# Altium schematic sheet Voltage_Sense.SchDoc
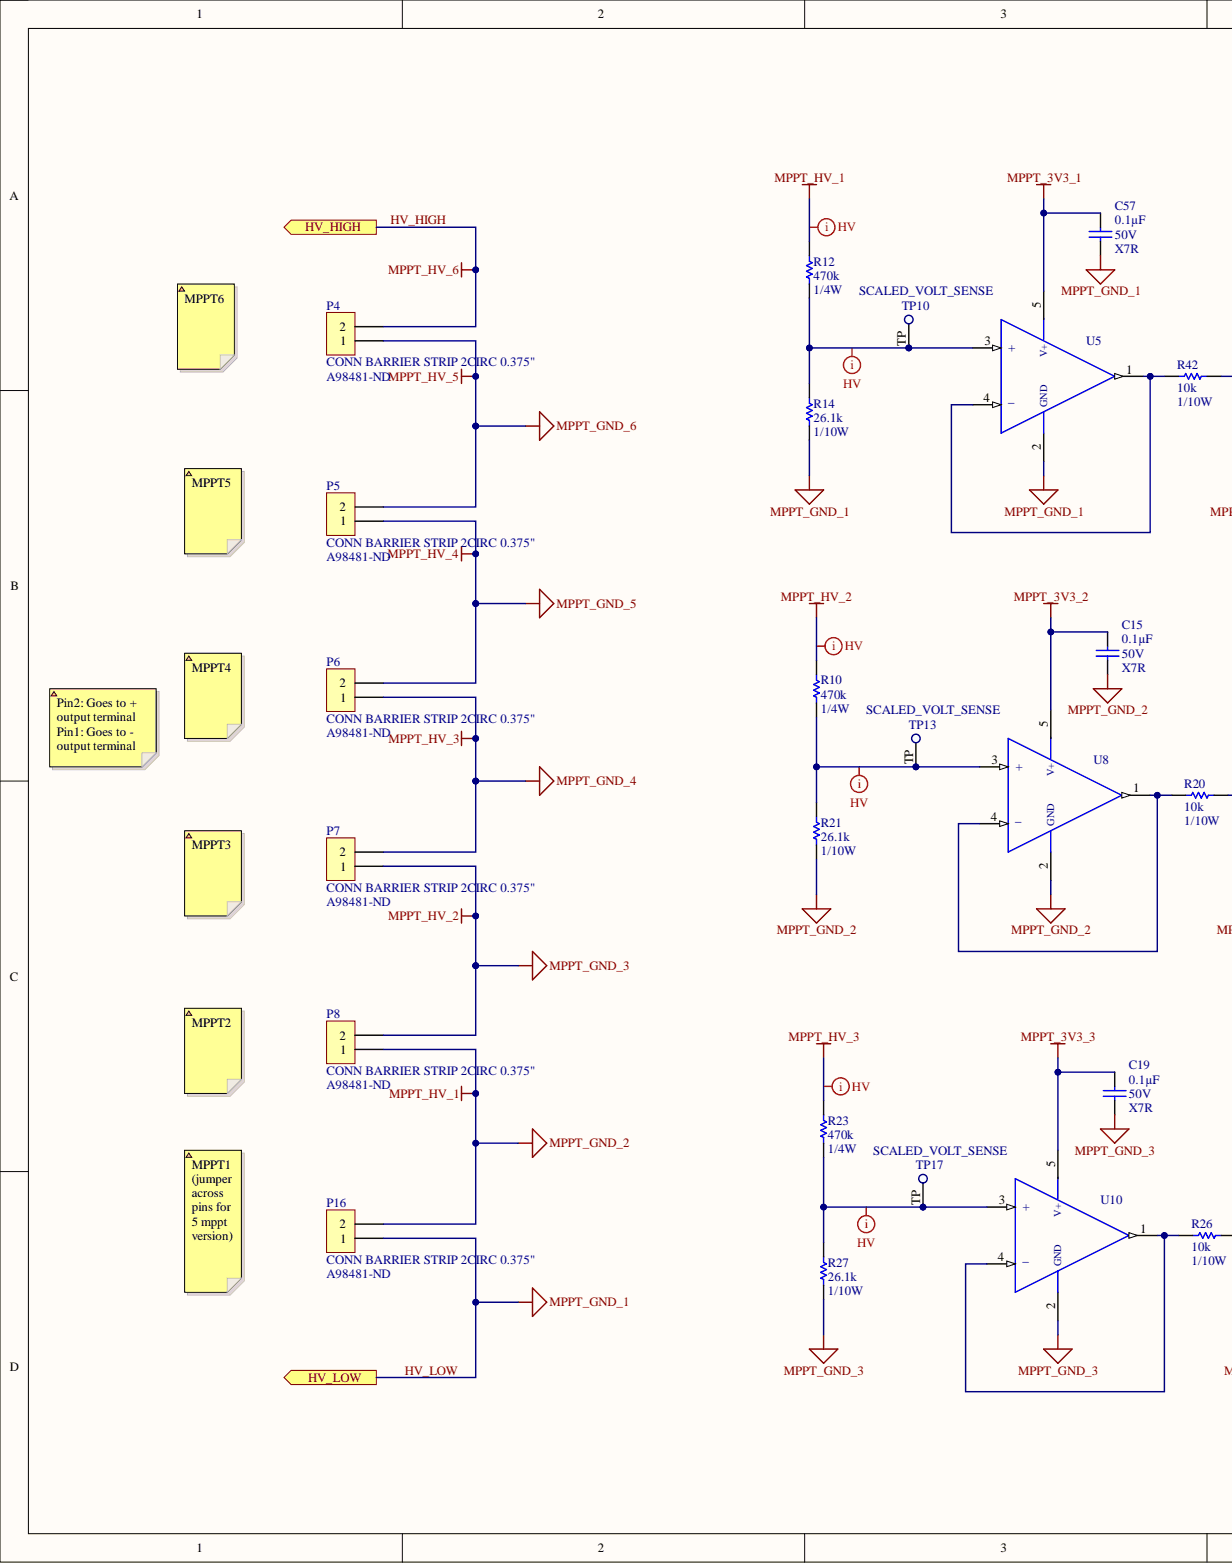
[diagram p0: Voltage_Sense.SchDoc, left]
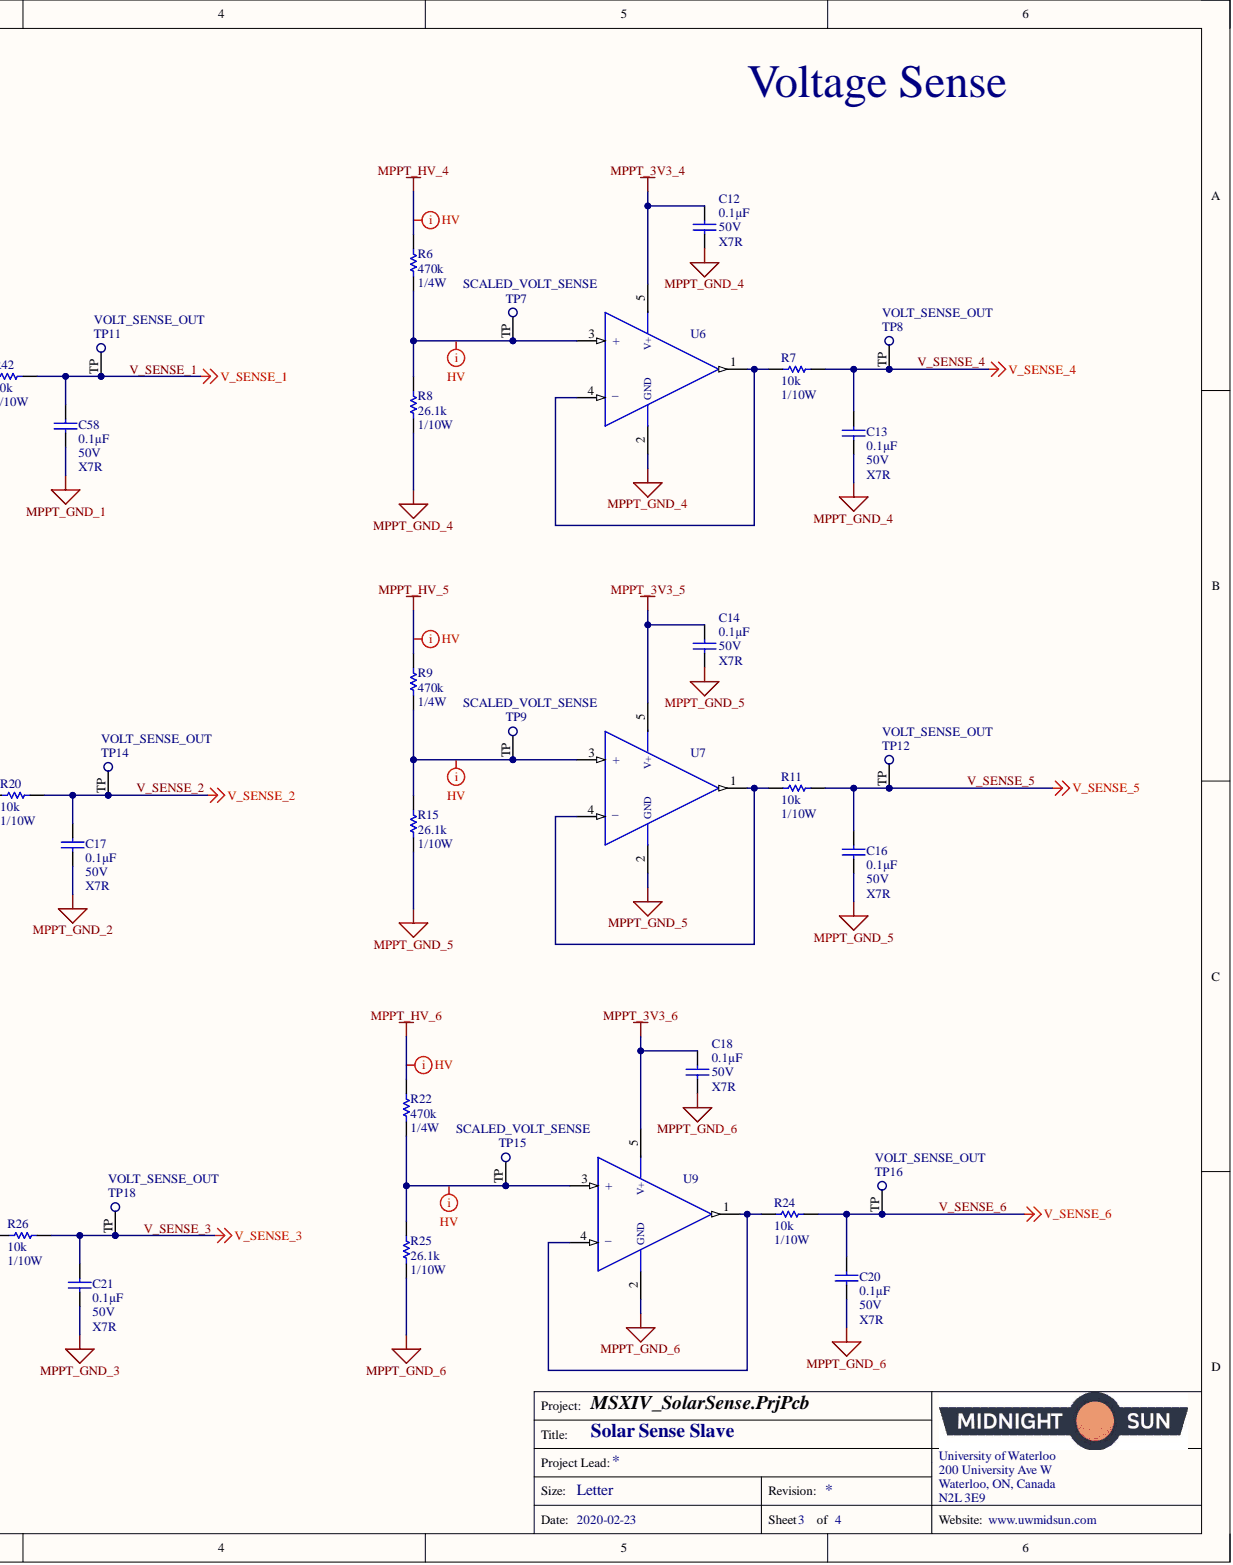
[diagram p1: Voltage_Sense.SchDoc, right]

## Parts
C12  Capacitance: 0.1µF  Temperature Coefficient: X7R  Voltage: 50V  [CAP, 0603]  (hidden comment: CAP CER 0.1UF 50V 10% X7R 0603)
C13  Capacitance: 0.1µF  Temperature Coefficient: X7R  Voltage: 50V  [CAP, 0603]  (hidden comment: CAP CER 0.1UF 50V 10% X7R 0603)
C14  Capacitance: 0.1µF  Temperature Coefficient: X7R  Voltage: 50V  [CAP, 0603]  (hidden comment: CAP CER 0.1UF 50V 10% X7R 0603)
C15  Capacitance: 0.1µF  Temperature Coefficient: X7R  Voltage: 50V  [CAP, 0603]  (hidden comment: CAP CER 0.1UF 50V 10% X7R 0603)
C16  Capacitance: 0.1µF  Temperature Coefficient: X7R  Voltage: 50V  [CAP, 0603]  (hidden comment: CAP CER 0.1UF 50V 10% X7R 0603)
C17  Capacitance: 0.1µF  Temperature Coefficient: X7R  Voltage: 50V  [CAP, 0603]  (hidden comment: CAP CER 0.1UF 50V 10% X7R 0603)
C18  Capacitance: 0.1µF  Temperature Coefficient: X7R  Voltage: 50V  [CAP, 0603]  (hidden comment: CAP CER 0.1UF 50V 10% X7R 0603)
C19  Capacitance: 0.1µF  Temperature Coefficient: X7R  Voltage: 50V  [CAP, 0603]  (hidden comment: CAP CER 0.1UF 50V 10% X7R 0603)
C20  Capacitance: 0.1µF  Temperature Coefficient: X7R  Voltage: 50V  [CAP, 0603]  (hidden comment: CAP CER 0.1UF 50V 10% X7R 0603)
C21  Capacitance: 0.1µF  Temperature Coefficient: X7R  Voltage: 50V  [CAP, 0603]  (hidden comment: CAP CER 0.1UF 50V 10% X7R 0603)
C57  Capacitance: 0.1µF  Temperature Coefficient: X7R  Voltage: 50V  [CAP, 0603]  (hidden comment: CAP CER 0.1UF 50V 10% X7R 0603)
C58  Capacitance: 0.1µF  Temperature Coefficient: X7R  Voltage: 50V  [CAP, 0603]  (hidden comment: CAP CER 0.1UF 50V 10% X7R 0603)
P4  CONN BARRIER STRIP 2CIRC 0.375"  Supplier Part Number 1: A98481-ND  [TE_3-1437652-9]
P5  CONN BARRIER STRIP 2CIRC 0.375"  Supplier Part Number 1: A98481-ND  [TE_3-1437652-9]
P6  CONN BARRIER STRIP 2CIRC 0.375"  Supplier Part Number 1: A98481-ND  [TE_3-1437652-9]
P7  CONN BARRIER STRIP 2CIRC 0.375"  Supplier Part Number 1: A98481-ND  [TE_3-1437652-9]
P8  CONN BARRIER STRIP 2CIRC 0.375"  Supplier Part Number 1: A98481-ND  [TE_3-1437652-9]
P16  CONN BARRIER STRIP 2CIRC 0.375"  Supplier Part Number 1: A98481-ND  [TE_3-1437652-9]
R6  Power: 1/4W  Resistance: 470k  [RES, 0603]  (hidden comment: RES 470K OHM 1% 1/4W 0603)
R7  Power: 1/10W  Resistance: 10k  [RES, 0603]  (hidden comment: RES 10K OHM 1% 1/10W 0603)
R8  Power: 1/10W  Resistance: 26.1k  [RES, 0603]  (hidden comment: RES 26.1K OHM 1% 1/10W 0603)
R9  Power: 1/4W  Resistance: 470k  [RES, 0603]  (hidden comment: RES 470K OHM 1% 1/4W 0603)
R10  Power: 1/4W  Resistance: 470k  [RES, 0603]  (hidden comment: RES 470K OHM 1% 1/4W 0603)
R11  Power: 1/10W  Resistance: 10k  [RES, 0603]  (hidden comment: RES 10K OHM 1% 1/10W 0603)
R12  Power: 1/4W  Resistance: 470k  [RES, 0603]  (hidden comment: RES 470K OHM 1% 1/4W 0603)
R14  Power: 1/10W  Resistance: 26.1k  [RES, 0603]  (hidden comment: RES 26.1K OHM 1% 1/10W 0603)
R15  Power: 1/10W  Resistance: 26.1k  [RES, 0603]  (hidden comment: RES 26.1K OHM 1% 1/10W 0603)
R20  Power: 1/10W  Resistance: 10k  [RES, 0603]  (hidden comment: RES 10K OHM 1% 1/10W 0603)
R21  Power: 1/10W  Resistance: 26.1k  [RES, 0603]  (hidden comment: RES 26.1K OHM 1% 1/10W 0603)
R22  Power: 1/4W  Resistance: 470k  [RES, 0603]  (hidden comment: RES 470K OHM 1% 1/4W 0603)
R23  Power: 1/4W  Resistance: 470k  [RES, 0603]  (hidden comment: RES 470K OHM 1% 1/4W 0603)
R24  Power: 1/10W  Resistance: 10k  [RES, 0603]  (hidden comment: RES 10K OHM 1% 1/10W 0603)
R25  Power: 1/10W  Resistance: 26.1k  [RES, 0603]  (hidden comment: RES 26.1K OHM 1% 1/10W 0603)
R26  Power: 1/10W  Resistance: 10k  [RES, 0603]  (hidden comment: RES 10K OHM 1% 1/10W 0603)
R27  Power: 1/10W  Resistance: 26.1k  [RES, 0603]  (hidden comment: RES 26.1K OHM 1% 1/10W 0603)
R42  Power: 1/10W  Resistance: 10k  [RES, 0603]  (hidden comment: RES 10K OHM 1% 1/10W 0603)
TP7  SCALED_VOLT_SENSE  [Test Point]  (no BOM)
TP8  VOLT_SENSE_OUT  [Test Point]
TP9  SCALED_VOLT_SENSE  [Test Point]  (no BOM)
TP10  SCALED_VOLT_SENSE  [Test Point]  (no BOM)
TP11  VOLT_SENSE_OUT  [Test Point]
TP12  VOLT_SENSE_OUT  [Test Point]
TP13  SCALED_VOLT_SENSE  [Test Point]  (no BOM)
TP14  VOLT_SENSE_OUT  [Test Point]
TP15  SCALED_VOLT_SENSE  [Test Point]  (no BOM)
TP16  VOLT_SENSE_OUT  [Test Point]
TP17  SCALED_VOLT_SENSE  [Test Point]  (no BOM)
TP18  VOLT_SENSE_OUT  [Test Point]
U5  IC OP AMP GEN PURPOSE RR 10MHZ SOT-23-5  [IC OP AMP GP RR 10MHZ SOT-23-5]  (value not drawn)
U6  IC OP AMP GEN PURPOSE RR 10MHZ SOT-23-5  [IC OP AMP GP RR 10MHZ SOT-23-5]  (value not drawn)
U7  IC OP AMP GEN PURPOSE RR 10MHZ SOT-23-5  [IC OP AMP GP RR 10MHZ SOT-23-5]  (value not drawn)
U8  IC OP AMP GEN PURPOSE RR 10MHZ SOT-23-5  [IC OP AMP GP RR 10MHZ SOT-23-5]  (value not drawn)
U9  IC OP AMP GEN PURPOSE RR 10MHZ SOT-23-5  [IC OP AMP GP RR 10MHZ SOT-23-5]  (value not drawn)
U10  IC OP AMP GEN PURPOSE RR 10MHZ SOT-23-5  [IC OP AMP GP RR 10MHZ SOT-23-5]  (value not drawn)

## Ports
HV_HIGH, HV_LOW

## Nets
MPPT_3V3_1: C57.1 U5.5
MPPT_3V3_2: C15.1 U8.5
MPPT_3V3_3: C19.1 U10.5
MPPT_3V3_4: C12.1 U6.5
MPPT_3V3_5: C14.1 U7.5
MPPT_3V3_6: C18.1 U9.5
MPPT_GND_2: C15.2 C17.2 P8.1 P16.2 R12.1 R21.2 U8.2
MPPT_GND_3: C19.2 C21.2 P7.1 P8.2 R10.1 R27.2 U10.2
MPPT_GND_4: C12.2 C13.2 P6.1 P7.2 R8.2 R23.1 U6.2
MPPT_GND_5: C14.2 C16.2 P5.1 P6.2 R6.1 R15.2 U7.2
MPPT_GND_6: C18.2 C20.2 P4.1 P5.2 R9.1 R25.2 U9.2
HV_HIGH: P4.2 R22.1 ; port HV_HIGH
HV_LOW: C57.2 C58.2 P16.1 R14.2 U5.2 ; port HV_LOW
V_SENSE_1: C58.1 R42.2 TP11.TP
V_SENSE_2: C17.1 R20.2 TP14.TP
V_SENSE_3: C21.1 R26.2 TP18.TP
V_SENSE_4: C13.1 R7.2 TP8.TP
V_SENSE_5: C16.1 R11.2 TP12.TP
V_SENSE_6: C20.1 R24.2 TP16.TP
NetR6_2: R6.2 R8.1 TP7.TP U6.3(+)
NetR7_1: R7.1 U6.1 U6.4
NetR9_2: R9.2 R15.1 TP9.TP U7.3(+)
NetR10_2: R10.2 R21.1 TP13.TP U8.3(+)
NetR11_1: R11.1 U7.1 U7.4
NetR12_2: R12.2 R14.1 TP10.TP U5.3(+)
NetR20_1: R20.1 U8.1 U8.4
NetR22_2: R22.2 R25.1 TP15.TP U9.3(+)
NetR23_2: R23.2 R27.1 TP17.TP U10.3(+)
NetR24_1: R24.1 U9.1 U9.4
NetR26_1: R26.1 U10.1 U10.4
NetR42_1: R42.1 U5.1 U5.4

## Library data of the parts
C12-C21 C57 C58 = CAP CER 0.1UF 50V 10% X7R 0603: 0.10µF ±10% 50V Ceramic Capacitor X7R 0603 (1608 Metric); Manufacturer 1: AVX Corporation; Manufacturer Part Number 1: 06035C104KAT2A; Component Kind: Standard; ComponentLink1Description: http://datasheets.avx.com/X7RDielectric.pdf; ComponentLink1URL: http://datasheets.avx.com/X7RDielectric.pdf; Height - Seated (Max): -; Lead Spacing: -; Lead Style: -; Mounting Type: Surface Mount, MLCC; Operating Temperature: -55°C ~ 125°C; Package / Case: 0603 (1608 Metric); Packaging: Cut Tape (CT); Part Status: Active; Ratings: -; Size / Dimension: 0.063" L x 0.032" W (1.60mm x 0.81mm); Supplier 1: Digi-Key; Supplier Part Number 1: 478-5052-1-ND; Thickness (Max): 0.035" (0.90mm); Tolerance: ±10%; Library Name: Schematic Diagrams.SchLib
P4-P8 P16 = CONN BARRIER STRIP 2CIRC 0.375": Barrier Terminal Blocks 2P TRI-BARRIER .375; Manufacturer: TE Connectivity; Manufacturer Part Number: 6PCV-02-006; Current Rating: 5A; Max Current Rating: 25A; Mounting Ears: No (Without); Number of Circuits: 2; Number of Levels: 1; Pitch: 9.53mm; Voltage: 300V; Wire Gauge (Max): 12AWG; Wire Gauge (Min): 22AWG; Category: Terminal Blocks; Color: Black; Component Kind: Standard; ComponentLink1Description: https://datasheet.ciiva.com/7978/1650932-7978603.pdf?src-supplier=Element14; ComponentLink1URL: https://datasheet.ciiva.com/7978/1650932-7978603.pdf?src-supplier=Element14; Contact Material: Copper Alloy; Contact Plating: Tin; ELV: Compliant; Flammability Rating: UL94 V-0; Height: 0.625inch; Housing Color: Black; Housing Material: Thermoplastic; Length: 0.811inch; Mount: Through Hole; Mounting Insert: No (Without); Number of Contacts: 2; Number of Positions: 2; Number of Rows: 1; Orientation: Straight; Package Quantity: 270; Packaging: Bulk; Pricing 1: 1=1.63000, 10=1.14400, 25=1.06680, 50=0.96820, 100=0.96400, 250=0.81404, 500=0.77122, 1000=0.68552, 5000=0.55699 (USD); REACH SVHC: Unknown; RoHS: Yes; Stock 1: 8088; Supplier 1: Digi-Key; Terminal Material: Copper Alloy; Termination: Solder; Voltage Rating: 300V; Width: 0.7inch; Wire/Cable Gauge: 22AWG; Library Name: Schematic Diagrams.SchLib
R6 R9 R10 R12 R22 R23 = RES 470K OHM 1% 1/4W 0603: RES SMD 470K OHM 1% 1/4W 0603; Manufacturer 1: Panasonic Electronic Components; Manufacturer Part Number 1: ERJ-PA3F4703V; Temperature Coefficient: ±100ppm/°C; Component Kind: Standard; ComponentLink1Description: https://industrial.panasonic.com/cdbs/www-data/pdf/RDO0000/AOA0000C291.pdf; ComponentLink1URL: https://industrial.panasonic.com/cdbs/www-data/pdf/RDO0000/AOA0000C291.pdf; Composition: Thick Film; Failure Rate: -; Height - Seated (Max): 0.022" (0.55mm); Operating Temperature: -55°C ~ 155°C; Package / Case: 0603 (1608 Metric); Packaging: Cut Tape (CT); Part Status: Active; Size / Dimension: 0.063" L x 0.031" W (1.60mm x 0.80mm); Supplier 1: Digi-Key; Supplier Device Package: 0603; Supplier Part Number 1: P470KBYCT-ND; Tolerance: ±1%; Library Name: Schematic Diagrams.SchLib
R7 R11 R20 R24 R26 R42 = RES 10K OHM 1% 1/10W 0603: RES SMD 10K OHM 1% 1/10W 0603; Manufacturer 1: Yageo; Manufacturer Part Number 1: RC0603FR-0710KL; Temperature Coefficient: ±100ppm/°C; Component Kind: Standard; ComponentLink1Description: http://www.yageo.com/documents/recent/PYu-RC_Group_51_RoHS_L_7.pdf; ComponentLink1URL: http://www.yageo.com/documents/recent/PYu-RC_Group_51_RoHS_L_7.pdf; Composition: Thick Film; Failure Rate: -; Height - Seated (Max): 0.022" (0.55mm); Operating Temperature: -55°C ~ 155°C; Package / Case: 0603 (1608 Metric); Packaging: Cut Tape (CT); Part Status: Active; Size / Dimension: 0.063" L x 0.031" W (1.60mm x 0.80mm); Supplier 1: Digi-Key; Supplier Device Package: 0603; Supplier Part Number 1: 311-10.0KHRCT-ND; Tolerance: ±1%; Library Name: Schematic Diagrams.SchLib
R8 R14 R15 R21 R25 R27 = RES 26.1K OHM 1% 1/10W 0603: RES SMD 26.1K OHM 1% 1/10W 0603; Manufacturer 1: Yageo; Manufacturer Part Number 1: RC0603FR-0726K1L; Temperature Coefficient: ±100ppm/°C; Component Kind: Standard; ComponentLink1Description: http://www.yageo.com/documents/recent/PYu-RC_Group_51_RoHS_L_7.pdf; ComponentLink1URL: http://www.yageo.com/documents/recent/PYu-RC_Group_51_RoHS_L_7.pdf; Composition: Thick Film; Failure Rate: -; Height - Seated (Max): 0.022" (0.55mm); Operating Temperature: -55°C ~ 155°C; Package / Case: 0603 (1608 Metric); Packaging: Cut Tape (CT); Part Status: Active; Size / Dimension: 0.063" L x 0.031" W (1.60mm x 0.80mm); Supplier 1: Digi-Key; Supplier Device Package: 0603; Supplier Part Number 1: 311-26.1KHRCT-ND; Tolerance: ±1%; Library Name: Schematic Diagrams.SchLib
TP7-TP18 = Test Point: Library Name: Schematic Diagrams.SchLib
  TP8 TP11 TP12 TP14 TP16 TP18: Component Kind: Standard
U5-U10 = IC OP AMP GEN PURPOSE RR 10MHZ SOT-23-5: General Purpose Amplifier 1 Circuit Rail-to-Rail SOT-23-5; Manufacturer 1: Texas Instruments; Manufacturer Part Number 1: TLV316QDBVRQ1; Current - Output / Channel: 50mA; Current - Supply: 400µA; Description 1: IC OP AMP GP RR 10MHZ 5-SOT-23; Number of Circuits: 1; Slew Rate: 6 V/µs; Voltage - Supply, Single/Dual (±): 1.8 V ~ 5.5 V, ±0.9 V ~ 2.75 V; -3db Bandwidth: -; Amplifier Type: General Purpose; Category: Integrated Circuits (ICs); Component Kind: Standard; ComponentLink1Description: http://www.ti.com/lit/ds/symlink/tlv316-q1.pdf; ComponentLink1URL: http://www.ti.com/lit/ds/symlink/tlv316-q1.pdf; Mounting Type: Surface Mount; Operating Temperature: -40°C ~ 125°C; Output Type: Rail-to-Rail; Package / Case: SC-74A, SOT-753; Packaging: Cut Tape (CT); Part Status: Active; Pricing 1: 1=1.33, 10=1.185, 100=0.9234, 500=0.76276, 1000=0.60218 (CAD); RoHS: RoHS Compliant; Stock 1: 1747; Supplier 1: Digi-Key; Supplier Device Package: SOT-23-5; Supplier Part Number 1: 296-45323-1-ND; Library Name: Schematic Diagrams.SchLib
